# Altium schematic sheet MING RTD.SchDoc
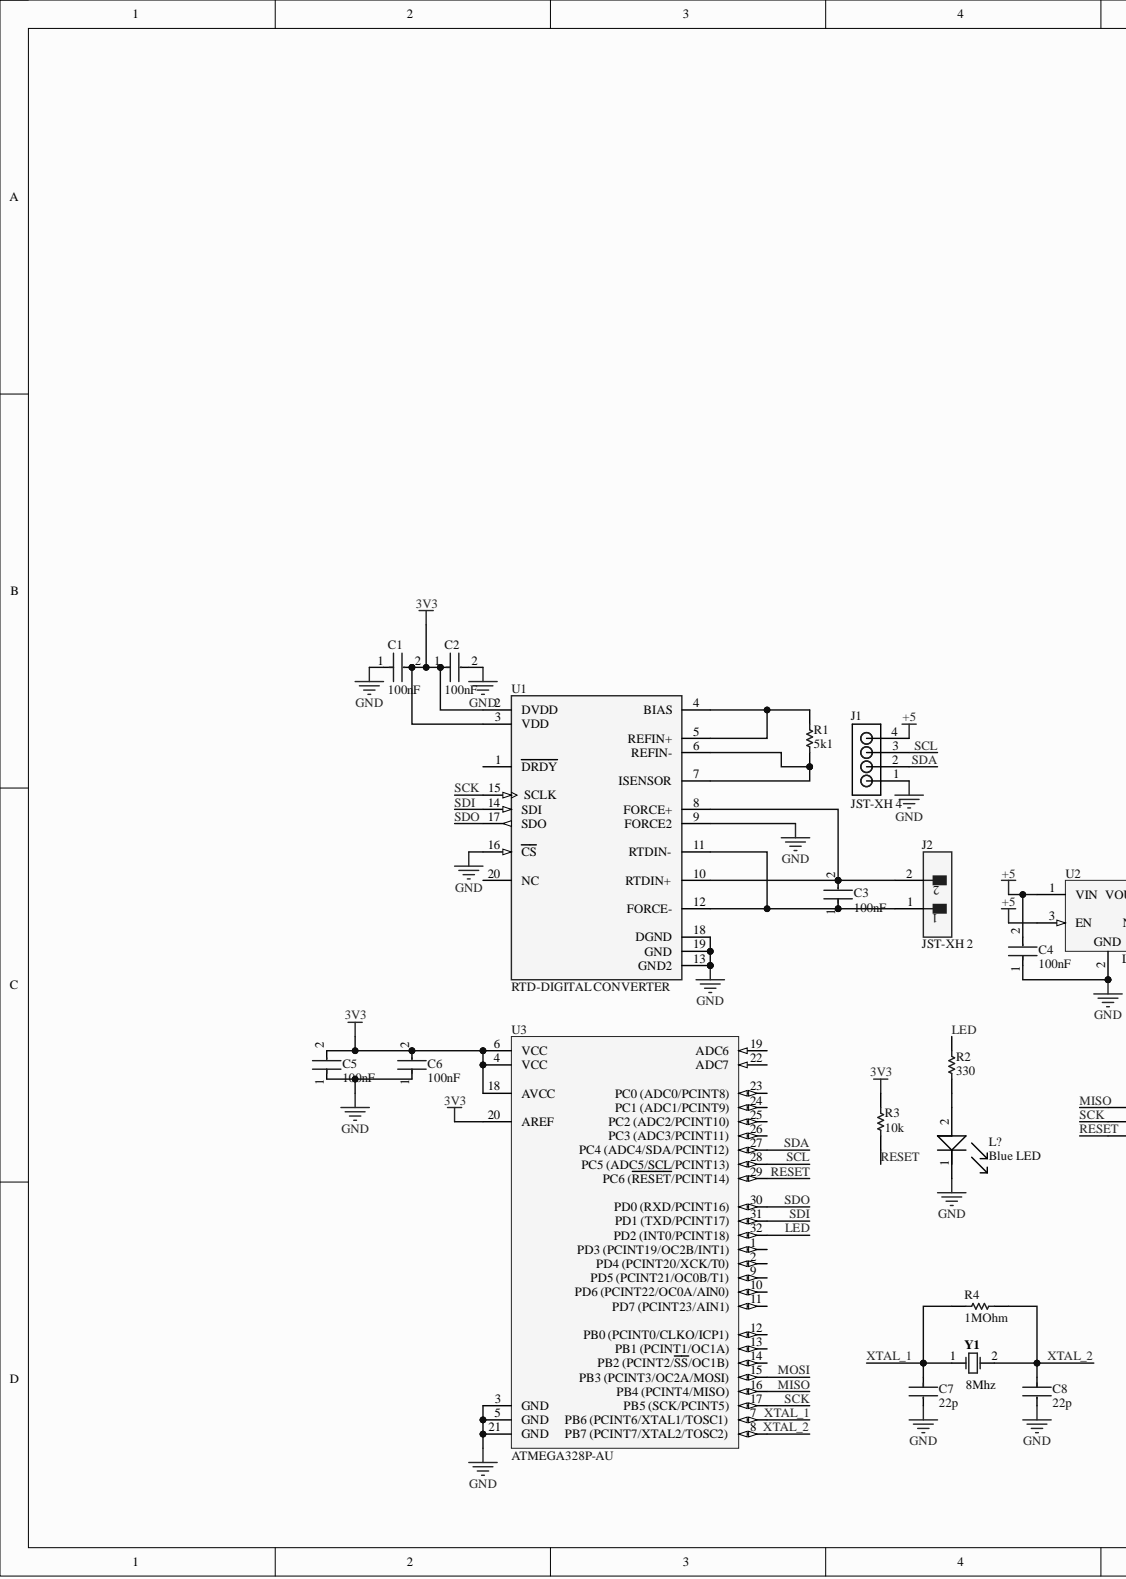
[diagram p0: MING RTD.SchDoc, left]
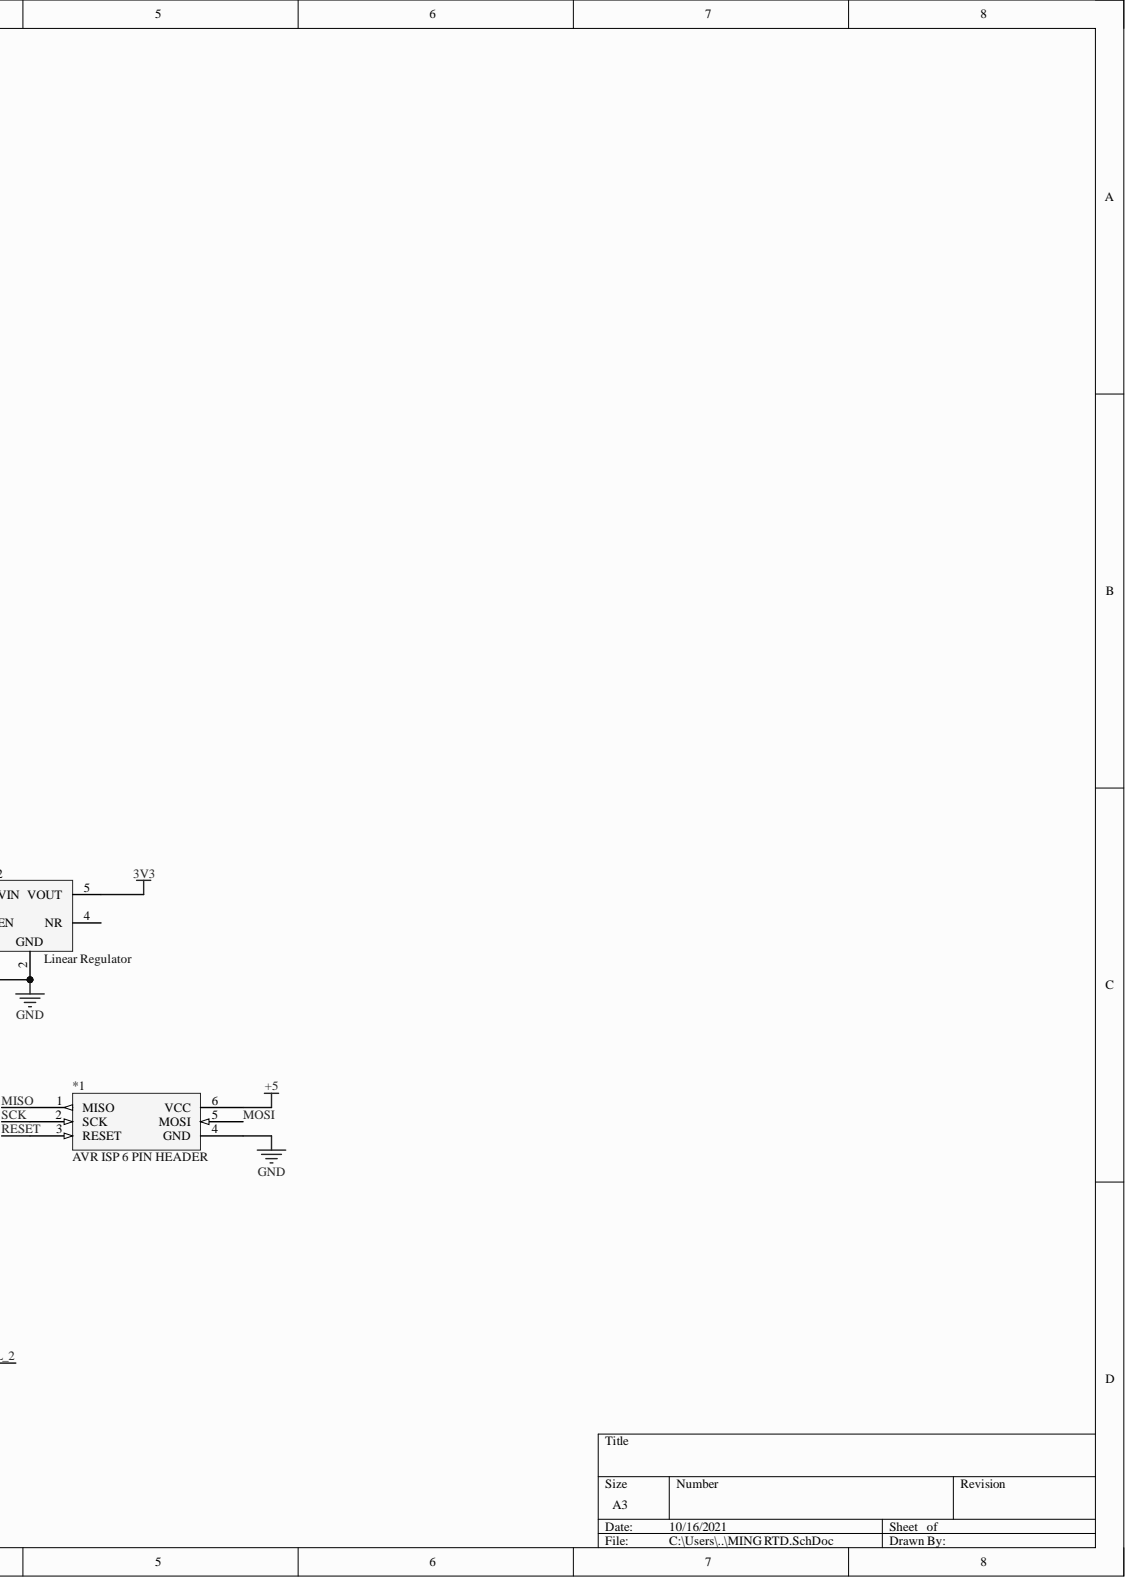
[diagram p1: MING RTD.SchDoc, right]

## Parts
*1  AVR ISP 6 PIN HEADER  [AVR ISP 6 PIN HEADER]
C1  100nF  [FP-GCM188-0_1-IPC_C]
C2  100nF  [FP-GCM188-0_1-IPC_C]
C3  100nF  [FP-GCM188-0_1-IPC_C]
C4  100nF  [FP-GCM188-0_1-IPC_C]
C5  100nF  [FP-GCM188-0_1-IPC_C]
C6  100nF  [FP-GCM188-0_1-IPC_C]
C7  22p  [CAPC1005X06L]
C8  22p  [CAPC1005X06L]
J1  JST-XH 4  [C146120]
J2  JST-XH 2  [FP-B2B-XH-A_LF_SN-MFG]
L?  Blue LED  [C165977]
R1  5k1  [RESC1608X06L]
R2  330  [RESC1608X06L]
R3  10k  [RESC1608X06L]
R4  1MOhm  [RESC1608X06L]
U1  RTD-DIGITAL CONVERTER  [FP-A20-1-IPC_C]
U2  Linear Regulator  [DBV0005A_L]
U3  ATMEGA328P-AU  [32A_L]
Y1  8Mhz  [C107425]

## Nets
3V3: C1.2 C2.1 C5.2 C6.2 R3.2 U1.2(DVDD) U1.3(VDD) U2.5(VOUT) U3.4(VCC) U3.6(VCC) U3.18(AVCC) U3.20(AREF)
+5: *1.6(VCC) C4.2 U2.1(VIN) U2.3(EN)
GND: *1.4(GND) C1.1 C2.2 C4.1 C5.1 C6.1 C7.2 C8.2 L?.1 U1.9(FORCE2) U1.13(GND2) U1.16(CS) U1.18(DGND) U1.19(GND) U2.2 U3.3(GND) U3.5(GND) U3.21(GND)
LED: R2.2 U3.32(PD2_(INT0/PCINT18))
MISO: *1.1(MISO) U3.16(PB4_(PCINT4/MISO))
MOSI: *1.5(MOSI) U3.15(PB3_(PCINT3/OC2A/MOSI))
RESET: *1.3(RESET) R3.1 U3.29(PC6_(RESET/PCINT14))
SCK: *1.2(SCK) U1.15(SCLK) U3.17(PB5_(SCK/PCINT5))
SCL: U3.28(PC5_(ADC5/SCL/PCINT13))
SDA: U3.27(PC4_(ADC4/SDA/PCINT12))
SDI: U1.14(SDI) U3.31(PD1_(TXD/PCINT17))
SDO: U1.17(SDO) U3.30(PD0_(RXD/PCINT16))
XTAL_1: C7.1 R4.1 U3.7 Y1.1
XTAL_2: C8.1 R4.2 U3.8 Y1.2
NetC3_1: C3.1 J2.1 U1.11(RTDIN-) U1.12(FORCE-)
NetC3_2: C3.2 J2.2 U1.8(FORCE+) U1.10(RTDIN+)
NetL?_2: L?.2 R2.1
NetR1_1: R1.1 U1.6(REFIN-) U1.7(ISENSOR)
NetR1_2: R1.2 U1.4(BIAS) U1.5(REFIN+)

Not connected: J1 1 2 3 4; U1 1(DRDY) 20(NC); U2 4(NR); U3 1(PD3_(PCINT19/OC2B/INT1)) 2(PD4_(PCINT20/XCK/T0)) 9(PD5_(PCINT21/OC0B/T1)) 10(PD6_(PCINT22/OC0A/AIN0)) 11(PD7_(PCINT23/AIN1)) 12(PB0_(PCINT0/CLKO/ICP1)) 13(PB1_(PCINT1/OC1A)) 14(PB2_(PCINT2/SS/OC1B)) 19(ADC6) 22(ADC7) 23(PC0_(ADC0/PCINT8)) 24(PC1_(ADC1/PCINT9)) 25 26(PC3_(ADC3/PCINT11))

## Library data of the parts
C1-C6 = C83054: Dielectric: X8R; Tolerance: 10%; Voltage Rating (DC): 25V; Capacitance: 100nF; Case/Package: 0603; Component Kind: Standard; Depth: 0.8mm; Lead Free: Lead Free; Length: 1.6mm; Library Name: Main.SchLib; Max Operating Temperature: 150°C; Min Operating Temperature: -55°C; Packaging: Tape and Reel; Supplier 1: Digi-Key
C7 C8 = C161315: CAPC1005X06L due to not in stock; Component Kind: Standard; Library Name: Main.SchLib
J1 = C146120: Component Kind: Standard; Library Name: Main.SchLib
J2 = C20079: CONN HEADER VERT 2POS 2.5MM; Connector Type: Male Pin; Contact Resistance: 20mR; Current Rating: 3A; Insulation Resistance: 1GR; Max Voltage Rating (AC): 250V; Number of Contacts: 2; Wire/Cable Gauge: 22AWG; Color: Natural; Component Kind: Standard; Contact Material: Brass; Contact Plating: Tin; Contact Type: Male Pin; Depth: 5.75mm; Fastening Type: Detent; Housing Color: White; Housing Material: Polyamide; Lead Free: Lead Free; Lead Pitch: 2.5mm; Length: 7.4mm; Library Name: Main.SchLib; Max Current Rating: 3A; Max Operating Temperature: 85°C; Max Voltage Rating (DC): 250V; Min Operating Temperature: -25°C; Mount: Through Hole; Number of Positions: 2; Number of Rows: 1; Orientation: Straight; Packaging: Bulk; Pitch: 2.5mm; REACH SVHC: No SVHC; Series: XH; Supplier 1: RSComponents; Supplier Part Number 1: 8201554; Termination: Solder; Voltage Rating: 250V; Voltage Rating (AC): 250V
L? = C165977: Component Kind: Standard; Library Name: Main.SchLib
R1 = C23186: Manufacturer: Panasonic; Part Number: ERJ-3EKF5101V; Component Kind: Standard; Library Name: Main.SchLib
R2 = C23138: Manufacturer: Panasonic; Part Number: ERJ1GNF1004C; Component Kind: Standard; Library Name: Main.SchLib
R3 = C25804: Manufacturer: Panasonic; Part Number: ERJ-3EKF5101V; Component Kind: Standard; Library Name: Main.SchLib
R4 = C22935: Manufacturer: Panasonic; Part Number: ERJ1GNF1004C; Component Kind: Standard; Library Name: Main.SchLib
U1 = C779509: IC RTD TO DIGITAL CONVERT 20SSOP; Output Type: SPI; Case/Package: SSOP; Component Kind: Standard; Library Name: Main.SchLib; Max Operating Temperature: 125°C; Min Operating Temperature: -40°C; Mount: Surface Mount; Packaging: Tape and Reel
U2 = C202242: Single Output Fast Transient Response LDO, 250 mA, Fixed 3.3 V Output, 1.8 to 12 V Input, 5-pin SOT-23 (DBV), -55 to 125 degC, Green (RoHS & no Sb/Br); Manufacturer: Texas Instruments; PartNumber: REG102NA-3.3/3K; Accuracy(%): 1.5; Fixed Output Options(V): 3.3; Iout(Max)(A): 0.25; Iq(Typ)(mA): 0.4; SubFamily: Single Channel LDO; Vdo(Typ)(mV): 150; Vin(Max)(V): 12; Vin(Min)(V): 1.8; Code_JEDEC: MO-178; Component Kind: Standard; ComponentLink1Description: Manufacturer URL; ComponentLink1URL: http://www.ti.com/; ComponentLink2Description: Datasheet; ComponentLink2URL: http://www.ti.com/general/docs/lit/getliterature.tsp?genericPartNumber=REG102-33&fileType=pdf; ComponentLink3Description: Package Specification; ComponentLink3URL: http://www.ti.com/litv/pdf/mpds018i; DatasheetVersion: SBVS024F; Eco-Plan: Green (RoHS & no Sb/Br); Library Name: Main.SchLib; Mounting Technology: Surface Mount; PackageDescription: 5-Pin Plastic Small Outline, Body 1.60 Ãƒâ€” 2.90 mm, Pitch 0.95 mm; PackageReference: DBV0005A; PackageVersion: 4073253-4/K; Vout Adj(Max)(V): 3.3; Vout Adj(Min)(V): 3.3
U3 = C14877: 8-bit AVR Microcontroller, 32KB Flash, 1KB EEPROM, 2KB SRAM, 32-pin TQFP, Industrial Grade (-40°C to 85°C); Code_JEDEC: MS-026-ABA; Component Kind: Standard; ComponentLink1Description: Manufacturer URL; ComponentLink1URL: http://www.atmel.com/; ComponentLink2Description: ATmega48A/48PA/88A/88PA/168A/168PA/328/328P Datasheet; ComponentLink2URL: http://www.atmel.com/dyn/resources/prod_documents/doc8271.pdf; ComponentLink3Description: ATmega48A/48PA/88A/88PA/168A/168PA/328/328P Summary; ComponentLink3URL: http://www.atmel.com/dyn/resources/prod_documents/8271S.pdf; DatasheetVersion: revD, May-2011; Library Name: Main.SchLib; PackageDescription: 32-Lead, 7x7x1.0 mm Body, 0.8mm Lead Pitch, Thin Profile Plastic Quad Flat Package (TQFP); PackageReference: 32A; PackageVersion: revC, Oct-2010; RevisionNote: DatasheetVersion & PackageVersion updated. URLs added.; Supplier 1: RSComponents; Supplier Part Number 1: 1310271
Y1 = C107425: Resistance Weld Crystal, 8 MHz, +/- 30 ppm, -20 to 70 degC, 2-Pin SMD, RoHS, Tape and Reel; Manufacturer: FOX Electronics; ESR (Equivalent Series Resistance): 80Ohm; Frequency Stability: 50ppm; Frequency Tolerance: 30ppm; Load Capacitance: 20pF; Case/Package: SMD/SMT; Component Kind: Standard; Datasheet URL: http://www.foxonline.com/pdfs/hc49sdlf.pdf; Datasheet Version: 42064.0; Depth: 5mm; Frequency: 8MHz; Height: 4.5mm; Lead Free: LeadFree; Length: 11.7mm; Library Name: Main.SchLib; Manufacturer URL: http://www.foxonline.com/; Max Operating Temperature: 70degC; Min Operating Temperature: -20degC; Mount: SurfaceMount; Number of Pins: 2; Package Description: 2-Pin Surface Mount Device, Body 5 x 11.7 mm; Package Quantity: 1000; Package Reference: HC49SDLF; Packaging: TapeandReel; Radiation Hardening: No; REACH SVHC: NoSVHC; RoHSCompliant: True; Series Resistance: 80Ohm; Termination: SMD/SMT; Tolerance: 50ppm; Width: 5mm
*1 = AVR ISP 6 PIN HEADER: Component Kind: Standard; Library Name: Main.SchLib
